# Altium schematic sheet LPC55S69_MINI.SchDoc(LPC55S69_MINI)
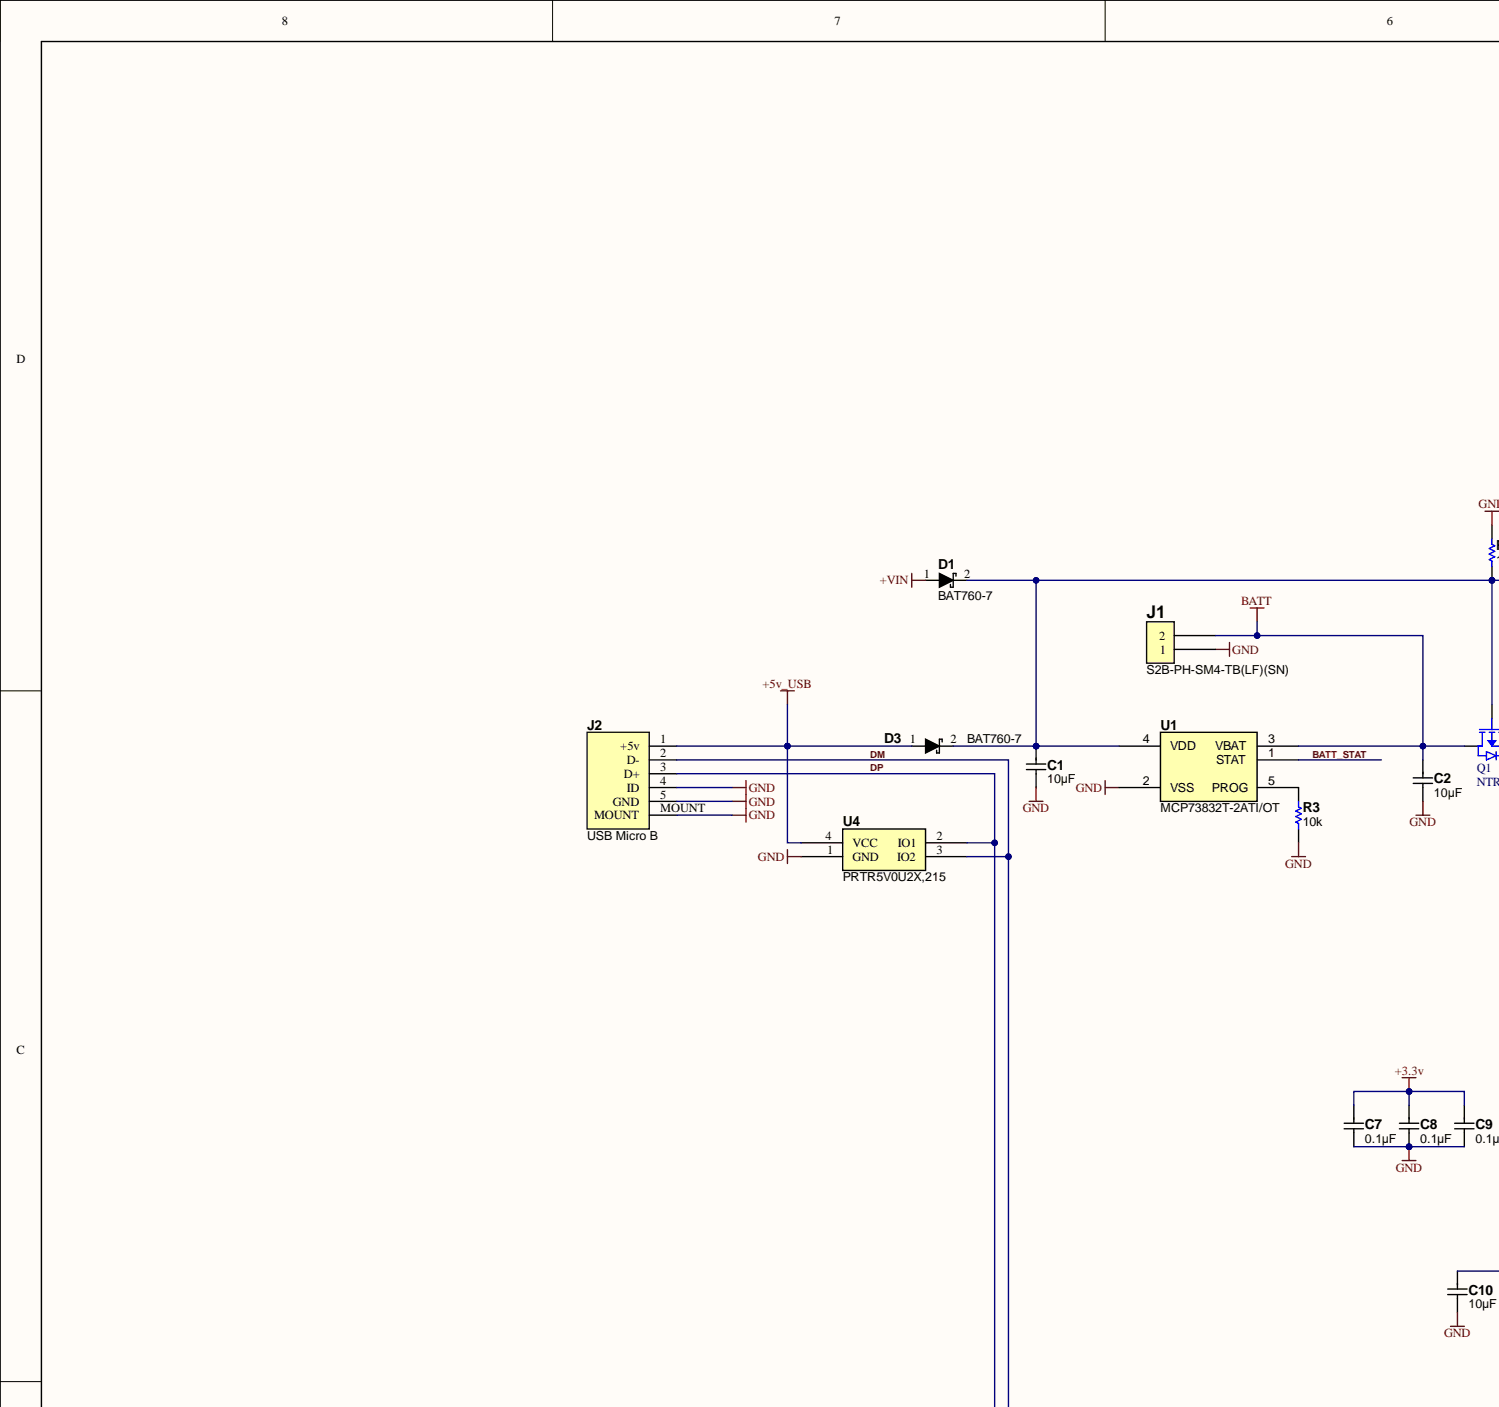
[diagram p0: LPC55S69_MINI.SchDoc, top left]
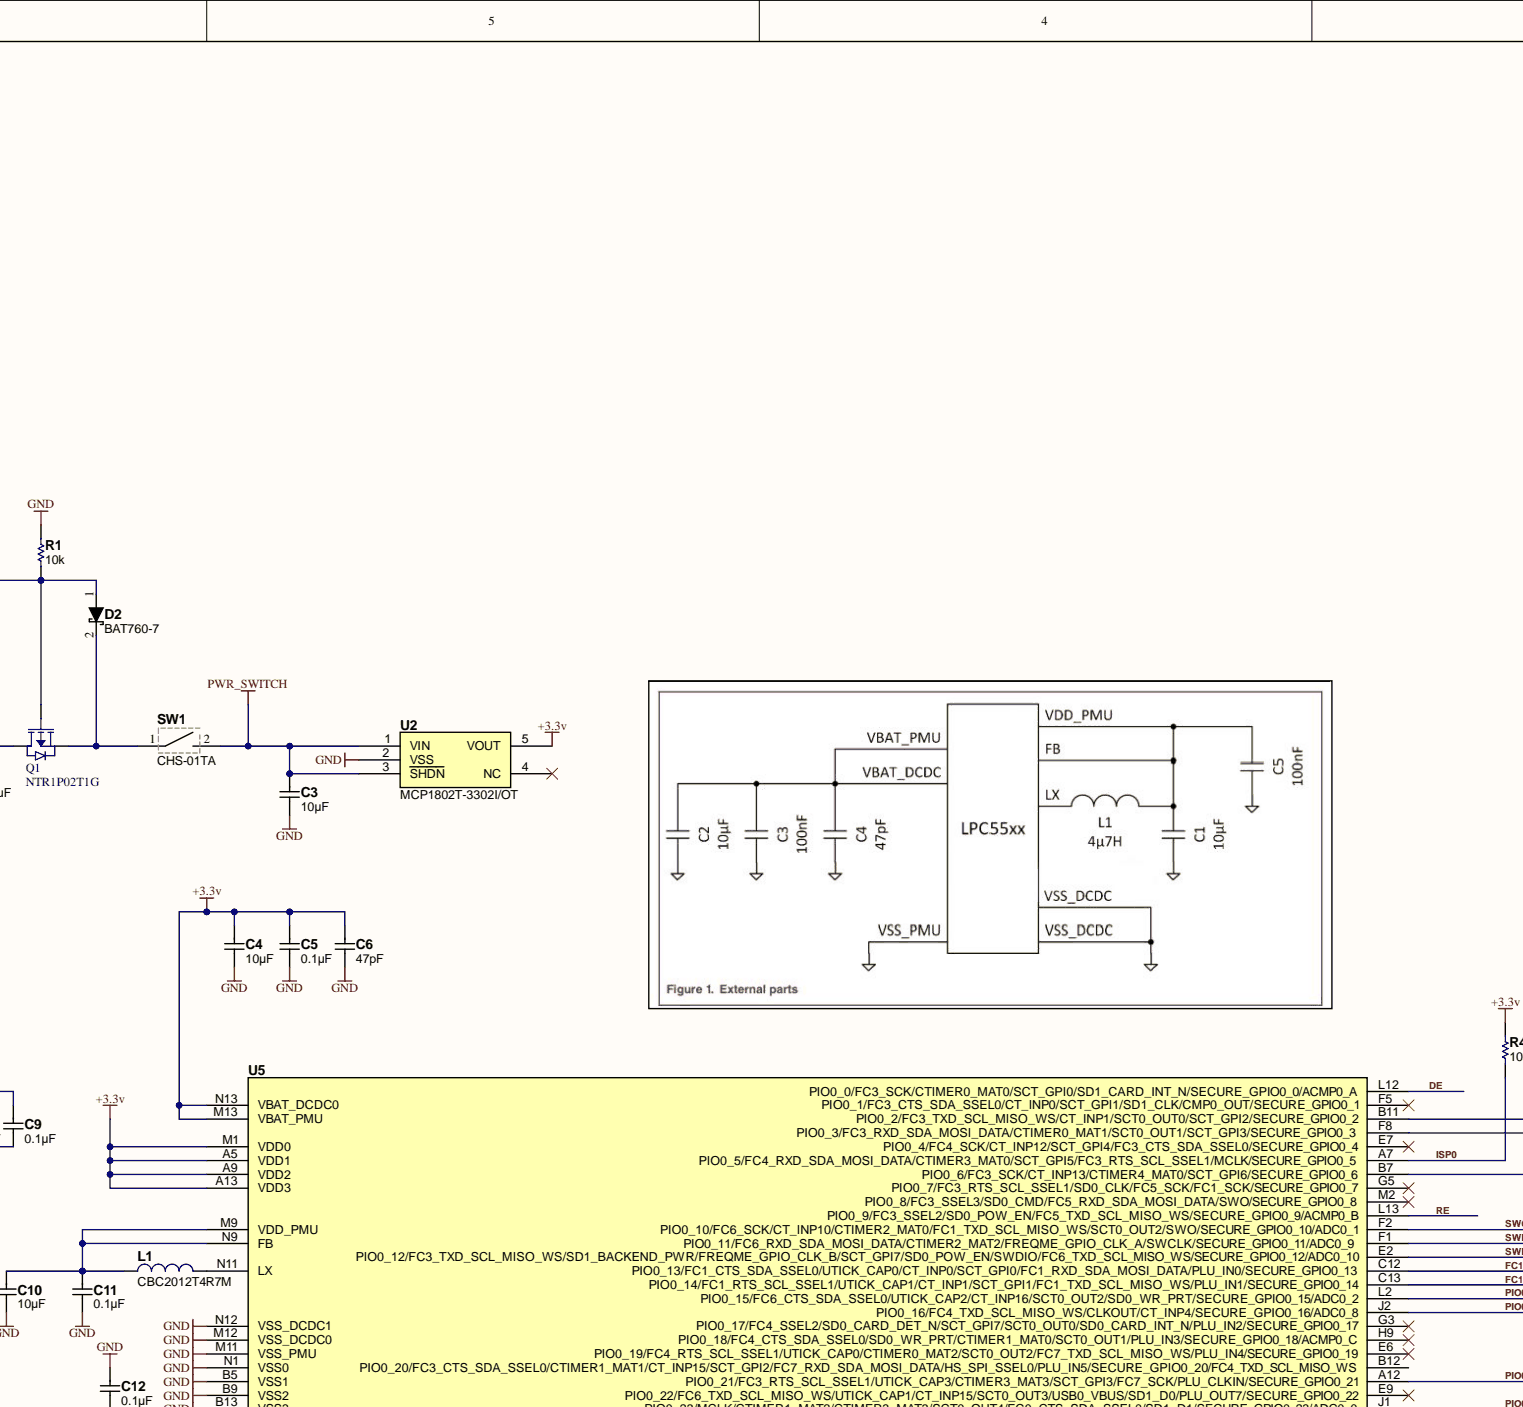
[diagram p1: LPC55S69_MINI.SchDoc, top centre]
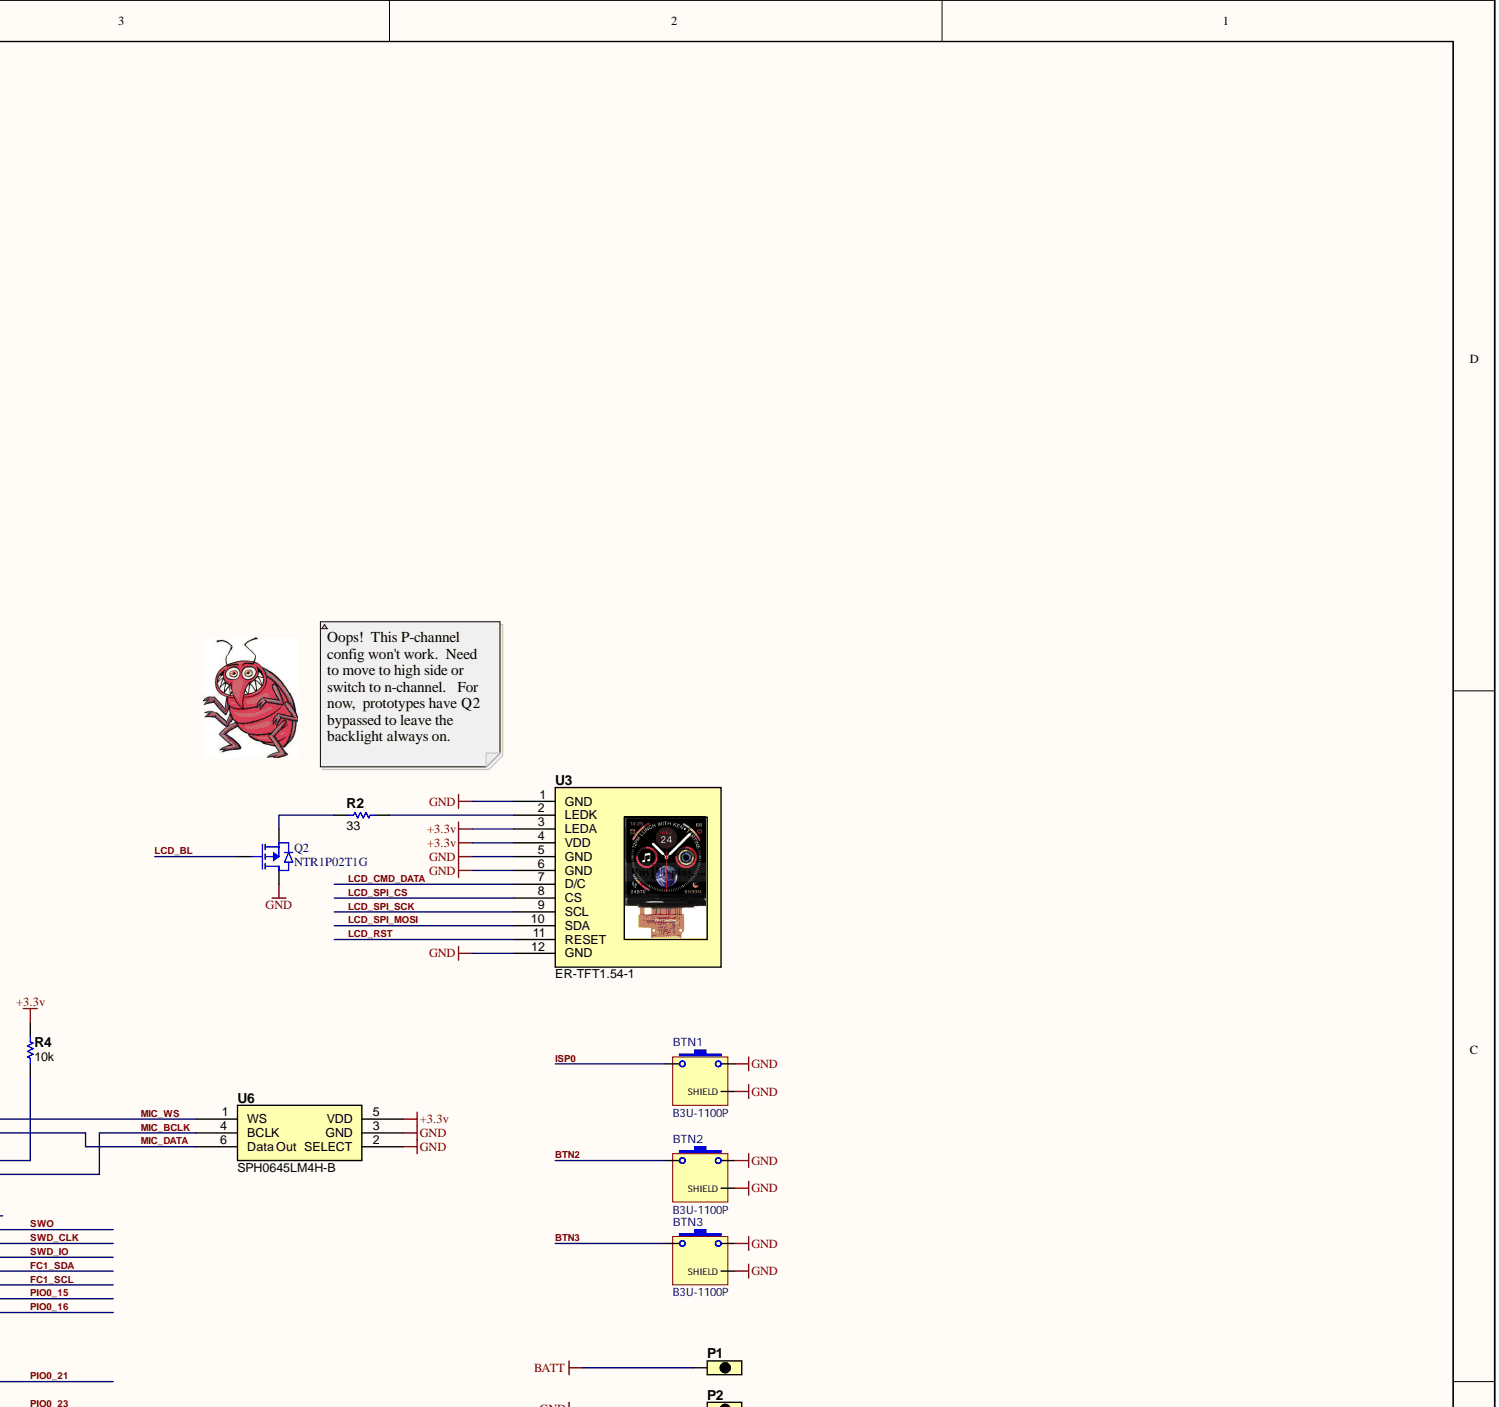
[diagram p2: LPC55S69_MINI.SchDoc, top right]
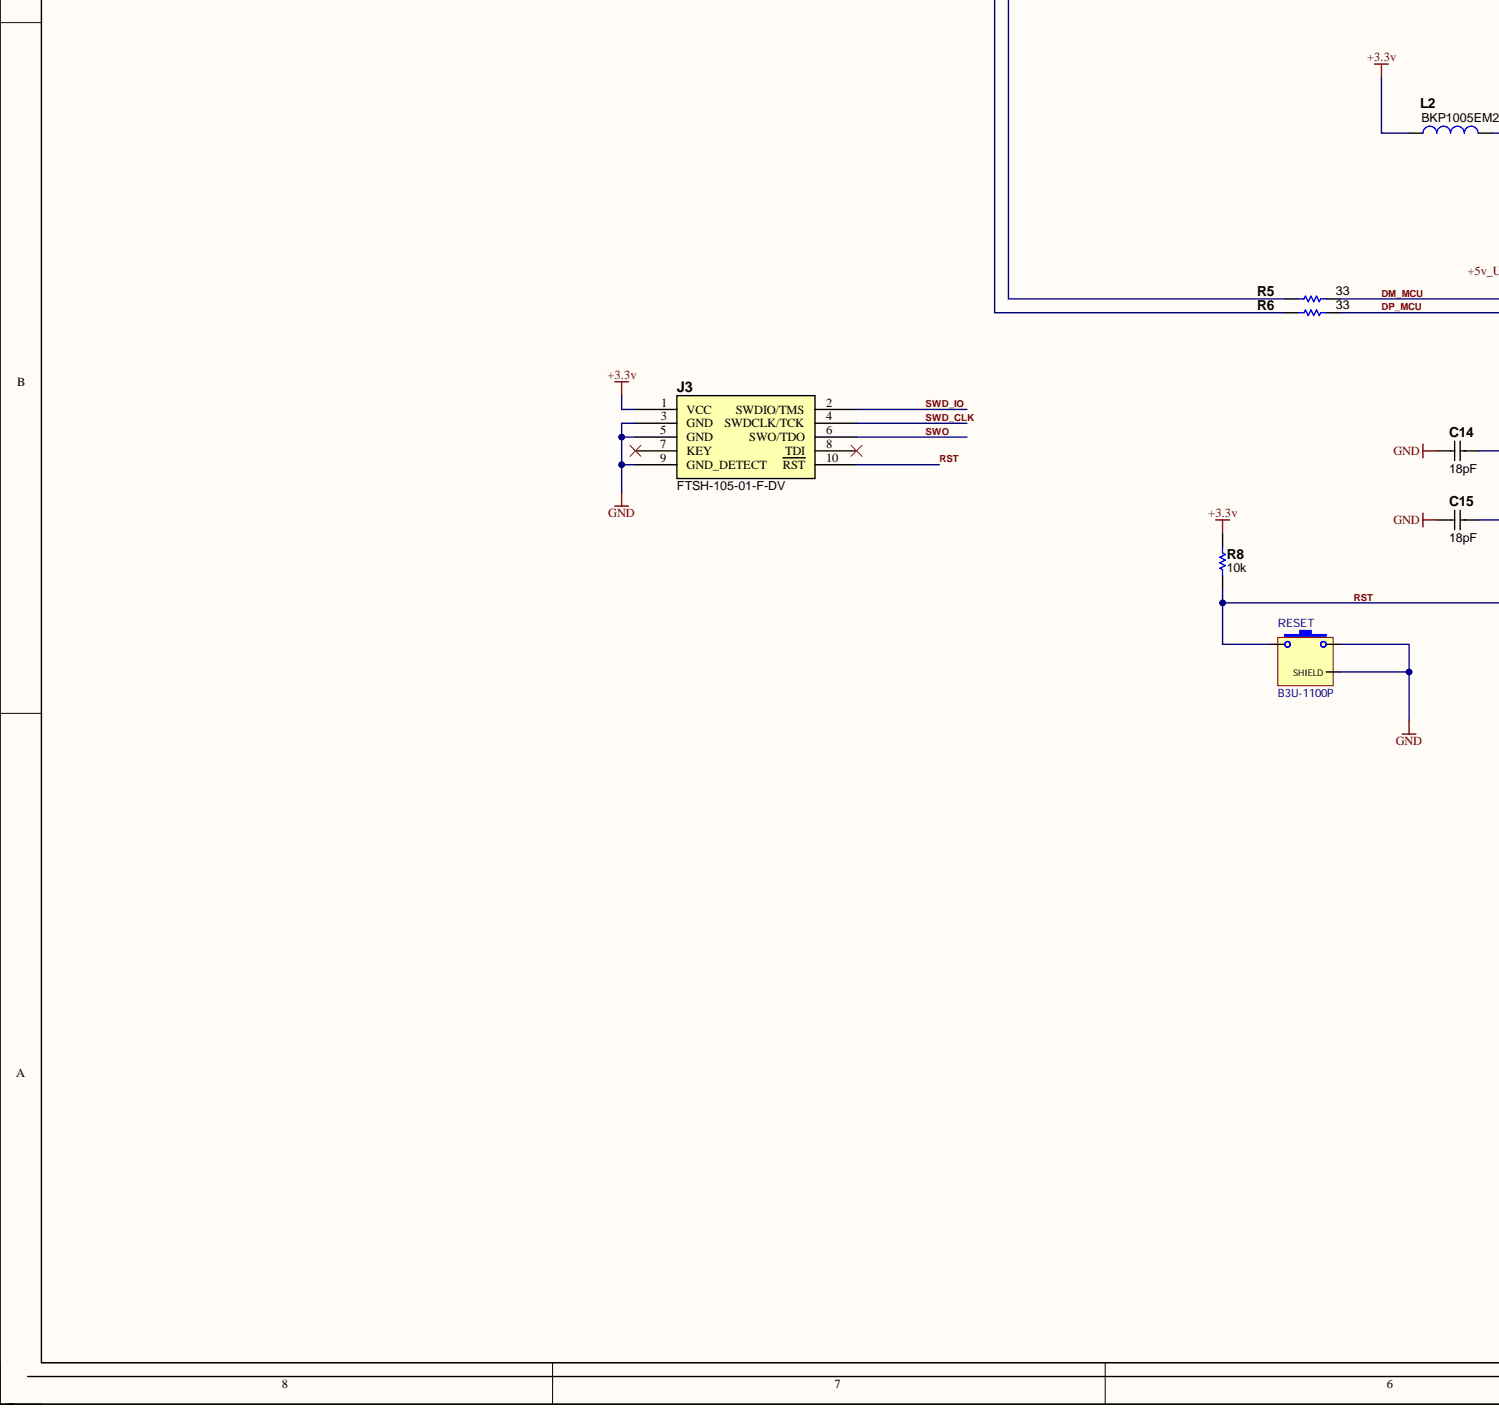
[diagram p3: LPC55S69_MINI.SchDoc, bottom left]
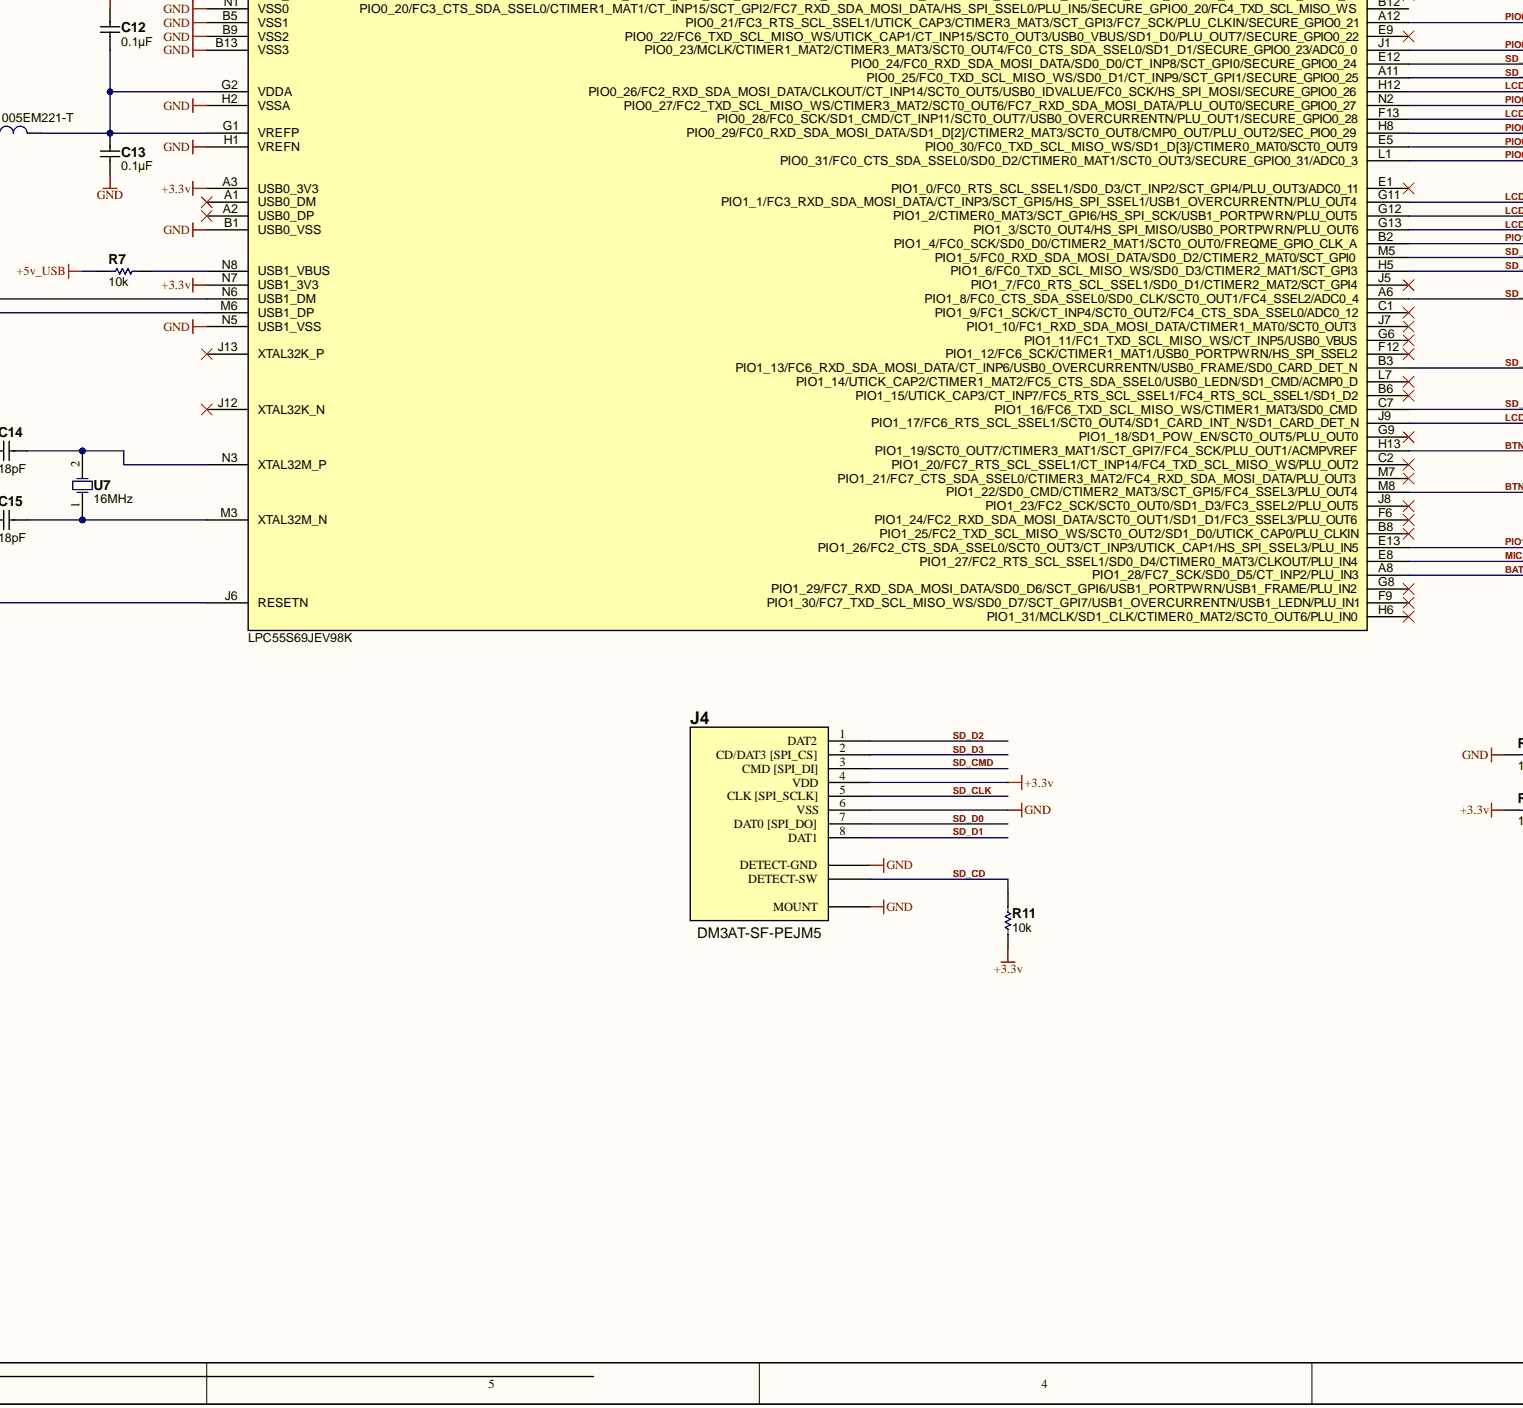
[diagram p4: LPC55S69_MINI.SchDoc, bottom centre]
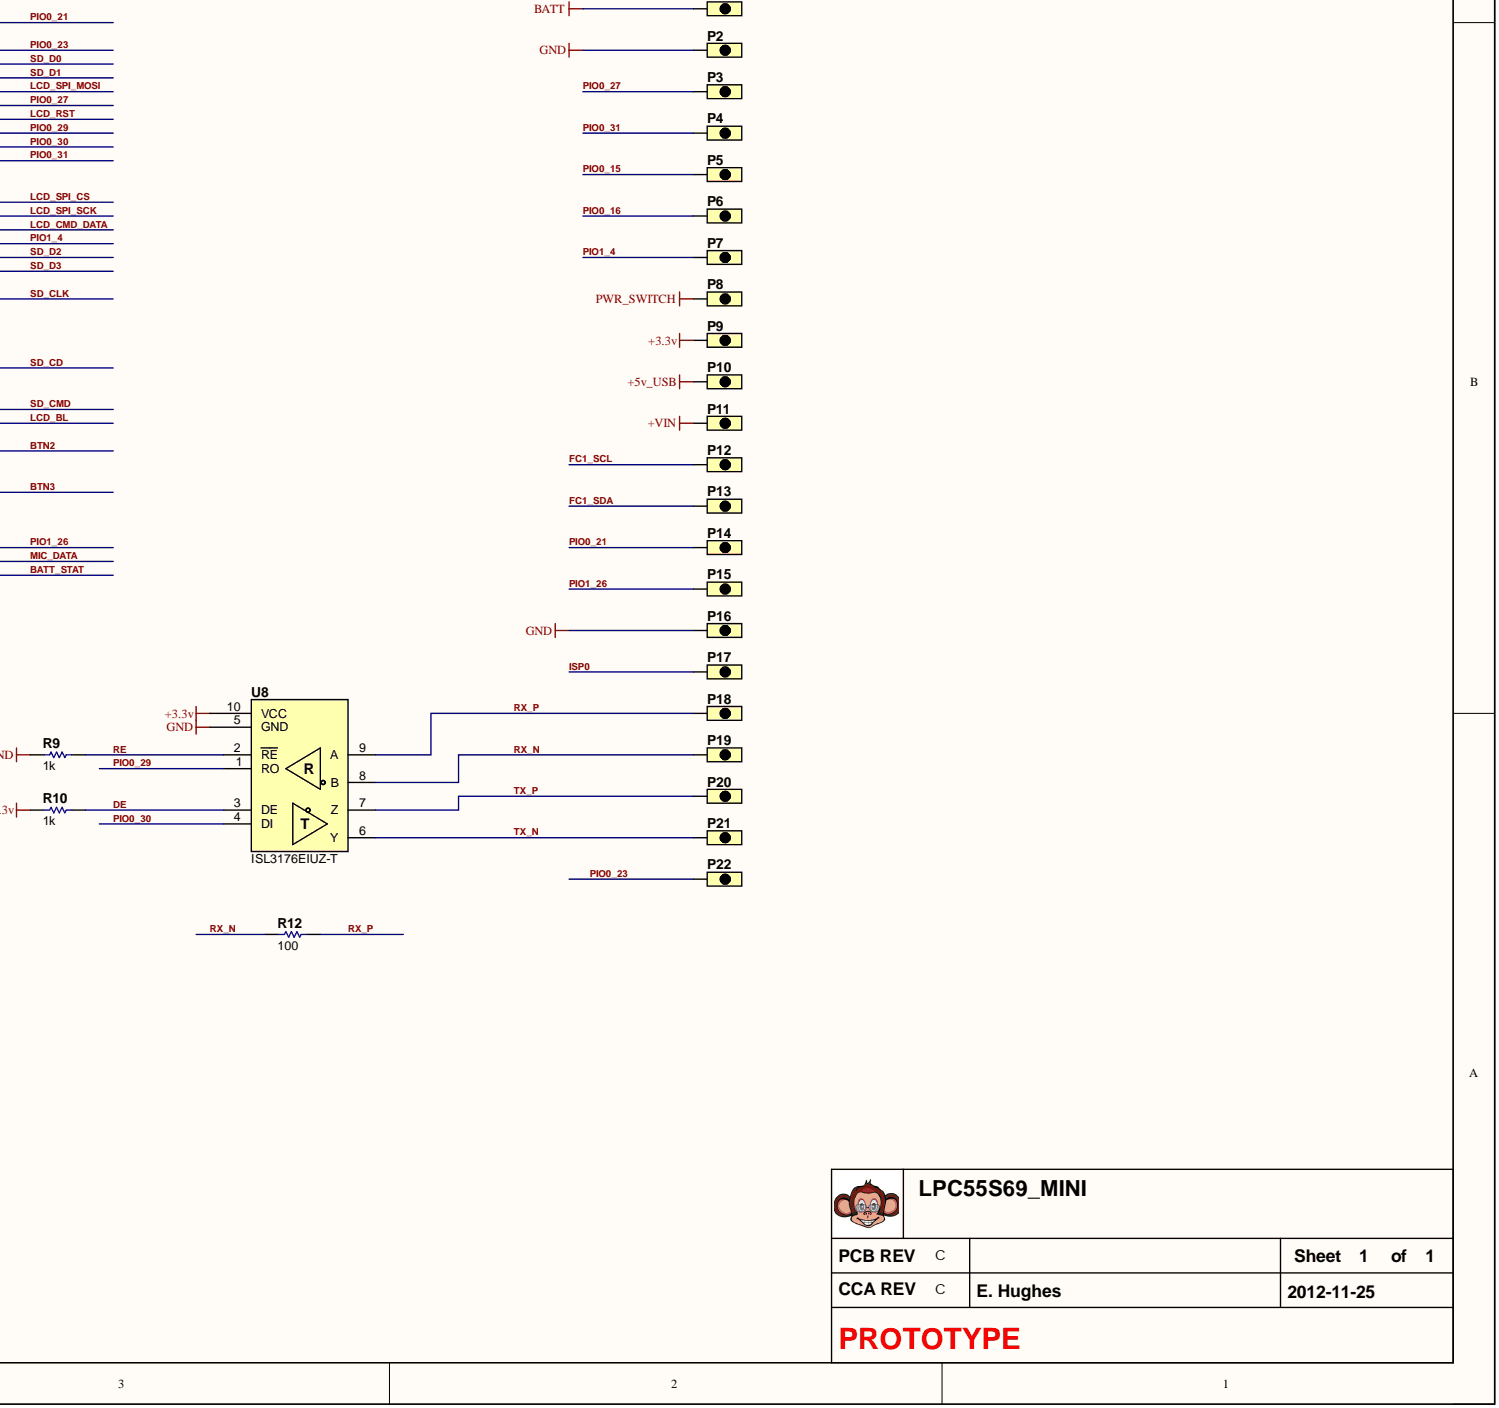
[diagram p5: LPC55S69_MINI.SchDoc, bottom right]

## Parts
BTN1  B3U-1100P  [OMRON_B3U-1100P]
BTN2  B3U-1100P  [OMRON_B3U-1100P]
BTN3  B3U-1100P  [OMRON_B3U-1100P]
C1  10µF  [C0805_1.45MM_MD]
C2  10µF  [C0805_1.45MM_MD]
C3  10µF  [C0805_1.45MM_MD]
C4  10µF  [C0805_1.45MM_MD]
C5  0.1µF  [C0402_0.55MM_MD]
C6  47pF  [C0402_0.55MM_MD]
C7  0.1µF  [C0402_0.55MM_MD]
C8  0.1µF  [C0402_0.55MM_MD]
C9  0.1µF  [C0402_0.55MM_MD]
C10  10µF  [C0805_1.45MM_MD]
C11  0.1µF  [C0402_0.55MM_MD]
C12  0.1µF  [C0402_0.55MM_MD]
C13  0.1µF  [C0402_0.55MM_MD]
C14  18pF  [C0402_0.55MM_MD]
C15  18pF  [C0402_0.55MM_MD]
D1  BAT760-7  [D_SOD-323_P]
D2  BAT760-7  [D_SOD-323_P]
D3  BAT760-7  [D_SOD-323_P]
J1  S2B-PH-SM4-TB(LF)(SN)  [S2B-PH-SM4-TB(LF)(SN)]
J2  USB Micro B  [10103594-0001LF - No Slots]
J3  FTSH-105-01-F-DV  [FTSH-105-01-F-DV-K-A]
J4  DM3AT-SF-PEJM5  [DM3AT-SF-PEJM5]
L1  CBC2012T4R7M  [C0805_1.45MM_MD]
L2  BKP1005EM221-T  [C0402_HD]
P1  (no value)  [CASTELLATED]  (no BOM)
P2  (no value)  [CASTELLATED]  (no BOM)
P3  (no value)  [CASTELLATED]  (no BOM)
P4  (no value)  [CASTELLATED]  (no BOM)
P5  (no value)  [CASTELLATED]  (no BOM)
P6  (no value)  [CASTELLATED]  (no BOM)
P7  (no value)  [CASTELLATED]  (no BOM)
P8  (no value)  [CASTELLATED]  (no BOM)
P9  (no value)  [CASTELLATED]  (no BOM)
P10  (no value)  [CASTELLATED]  (no BOM)
P11  (no value)  [CASTELLATED]  (no BOM)
P12  (no value)  [CASTELLATED]  (no BOM)
P13  (no value)  [CASTELLATED]  (no BOM)
P14  (no value)  [CASTELLATED]  (no BOM)
P15  (no value)  [CASTELLATED]  (no BOM)
P16  (no value)  [CASTELLATED]  (no BOM)
P17  (no value)  [CASTELLATED]  (no BOM)
P18  (no value)  [CASTELLATED]  (no BOM)
P19  (no value)  [CASTELLATED]  (no BOM)
P20  (no value)  [CASTELLATED]  (no BOM)
P21  (no value)  [CASTELLATED]  (no BOM)
P22  (no value)  [CASTELLATED]  (no BOM)
Q1  NTR1P02T1G  [SOT-23]
Q2  NTR1P02T1G  [SOT-23]
R1  10k  [R0402_0.40MM_MD]
R2  33  [R0603_0.55MM_MD]
R3  10k  [R0402_0.40MM_MD]
R4  10k  [R0402_0.40MM_MD]
R5  33  [R0402_0.40MM_MD]
R6  33  [R0402_0.40MM_MD]
R7  10k  [R0402_0.40MM_MD]
R8  10k  [R0402_0.40MM_MD]
R9  1k  [R0402_0.40MM_MD]
R10  1k  [R0402_0.40MM_MD]
R11  10k  [R0402_0.40MM_MD]
R12  100  [R0603_HD]
RESET  B3U-1100P  [OMRON_B3U-1100P]
SW1  CHS-01TA  [CHS-01TA]
U1  MCP73832T-2ATI/OT  [SOT23-5]
U2  MCP1802T-3302I/OT  [SOT23-5]
U3  ER-TFT1.54-1  [ER-TFT1.54-1]
U4  PRTR5V0U2X,215  [SOT143B]
U5  LPC55S69JEV98K  [98-VFBGA]
U6  SPH0645LM4H-B  [SPH0645LM4H-B]
U7  16MHz  [ABM3]
U8  ISL3176EIUZ-T  [10 MSOP]

## Nets
+3.3v: C4.2 C5.2 C6.2 C7.2 C8.2 C9.2 J3.1(VCC) J4.4(VDD) L2.1 P9.1 R4.2 R8.2 R10.1 R11.1 U2.5(VOUT) U3.3(LEDA) U3.4(VDD) U5.A3(USB0_3V3) U5.A5(VDD1) U5.A9(VDD2) U5.A13(VDD3) U5.M1(VDD0) U5.M13(VBAT_PMU) U5.N7(USB1_3V3) U5.N13(VBAT_DCDC0) U6.5(VDD) U8.10(VCC)
+5v_USB: D3.1 J2.1(+5v) P10.1 R7.2 U4.4(VCC)
+VIN: D1.1 P11.1
BATT: C2.2 J1.2 P1.1 Q1.3 U1.3(VBAT)
GND: BTN1.1 BTN1.S BTN2.1 BTN2.S BTN3.1 BTN3.S C1.1 C2.1 C3.1 C4.1 C5.1 C6.1 C7.1 C8.1 C9.1 C10.1 C11.1 C12.2 C13.1 C14.1 C15.1 J1.1 J2.4(ID) J2.5(GND) J2.MOUNT J3.3(GND) J3.5(GND) J3.9(GND_DETECT) J4.6(VSS) J4.CDA(DETECT-GND) J4.M(MOUNT) P2.1 P16.1 Q2.3 R1.2 R3.1 R9.1 RESET.1 RESET.S U1.2(VSS) U2.2(VSS) U3.1(GND) U3.5(GND) U3.6(GND) U3.12(GND) U4.1(GND) U5.B1(USB0_VSS) U5.B5(VSS1) U5.B9(VSS2) U5.B13(VSS3) U5.H1(VREFN) U5.H2(VSSA) U5.M11(VSS_PMU) U5.M12(VSS_DCDC0) U5.N1(VSS0) U5.N5(USB1_VSS) U5.N12(VSS_DCDC1) U6.2(SELECT) U6.3(GND) U8.5(GND)
PWR_SWITCH: C3.2 P8.1 SW1.2 U2.1(VIN) U2.3(SHDN)
BATT_STAT: U1.1(STAT) U5.A8(PIO1_28/FC7_SCK/SD0_D5/CT_INP2/PLU_IN3)
BTN2: BTN2.2 U5.H13(PIO1_19/SCT0_OUT7/CTIMER3_MAT1/SCT_GPI7/FC4_SCK/PLU_OUT1/ACMPVREF)
BTN3: BTN3.2 U5.M8(PIO1_22/SD0_CMD/CTIMER2_MAT3/SCT_GPI5/FC4_SSEL3/PLU_OUT4)
DE: R10.2 U5.L12 U8.3(DE)
DM: J2.2(D-) R5.1 U4.3(IO2)
DM_MCU: R5.2 U5.N6(USB1_DM)
DP: J2.3(D+) R6.1 U4.2(IO1)
DP_MCU: R6.2 U5.M6(USB1_DP)
FC1_SCL: P12.1 U5.C13
FC1_SDA: P13.1 U5.C12
ISP0: BTN1.2 P17.1 R4.1 U5.A7
LCD_BL: Q2.1 U5.J9(PIO1_17/FC6_RTS_SCL_SSEL1/SCT0_OUT4/SD1_CARD_INT_N/SD1_CARD_DET_N)
LCD_CMD_DATA: U3.7(D/C) U5.G13(PIO1_3/SCT0_OUT4/HS_SPI_MISO/USB0_PORTPWRN/PLU_OUT6)
LCD_RST: U3.11(RESET) U5.F13
LCD_SPI_CS: U3.8(CS) U5.G11(PIO1_1/FC3_RXD_SDA_MOSI_DATA/CT_INP3/SCT_GPI5/HS_SPI_SSEL1/USB1_OVERCURRENTN/PLU_OUT4)
LCD_SPI_MOSI: U3.10(SDA) U5.H12
LCD_SPI_SCK: U3.9(SCL) U5.G12(PIO1_2/CTIMER0_MAT3/SCT_GPI6/HS_SPI_SCK/USB1_PORTPWRN/PLU_OUT5)
MIC_BCLK: U5.B7 U6.4(BCLK)
MIC_DATA: U5.E8(PIO1_27/FC2_RTS_SCL_SSEL1/SD0_D4/CTIMER0_MAT3/CLKOUT/PLU_IN4) U5.F8 U6.6(Data_Out)
MIC_WS: U5.B11 U6.1(WS)
PIO0_15: P5.1 U5.L2
PIO0_16: P6.1 U5.J2
PIO0_21: P14.1 U5.A12
PIO0_23: P22.1 U5.J1
PIO0_27: P3.1 U5.N2
PIO0_29: U5.H8 U8.1(RO)
PIO0_30: U5.E5 U8.4(DI)
PIO0_31: P4.1 U5.L1
PIO1_4: P7.1 U5.B2(PIO1_4/FC0_SCK/SD0_D0/CTIMER2_MAT1/SCT0_OUT0/FREQME_GPIO_CLK_A)
PIO1_26: P15.1 U5.E13(PIO1_26/FC2_CTS_SDA_SSEL0/SCT0_OUT3/CT_INP3/UTICK_CAP1/HS_SPI_SSEL3/PLU_IN5)
RE: R9.2 U5.L13 U8.2(RE)
RST: J3.10(RST) R8.1 RESET.2 U5.J6(RESETN)
RX_N: P19.1 R12.2 U8.8(B)
RX_P: P18.1 R12.1 U8.9(A)
SD_CD: J4.CDB(DETECT-SW) R11.2 U5.B3(PIO1_13/FC6_RXD_SDA_MOSI_DATA/CT_INP6/USB0_OVERCURRENTN/USB0_FRAME/SD0_CARD_DET_N)
SD_CLK: J4.5(CLK_[SPI_SCLK]) U5.A6(PIO1_8/FC0_CTS_SDA_SSEL0/SD0_CLK/SCT0_OUT1/FC4_SSEL2/ADC0_4)
SD_CMD: J4.3(CMD_[SPI_DI]) U5.C7(PIO1_16/FC6_TXD_SCL_MISO_WS/CTIMER1_MAT3/SD0_CMD)
SD_D0: J4.7(DAT0_[SPI_DO]) U5.E12
SD_D1: J4.8(DAT1) U5.A11
SD_D2: J4.1(DAT2) U5.M5(PIO1_5/FC0_RXD_SDA_MOSI_DATA/SD0_D2/CTIMER2_MAT0/SCT_GPI0)
SD_D3: J4.2(CD/DAT3_[SPI_CS]) U5.H5(PIO1_6/FC0_TXD_SCL_MISO_WS/SD0_D3/CTIMER2_MAT1/SCT_GPI3)
SWD_CLK: J3.4(SWDCLK/TCK) U5.F1
SWD_IO: J3.2(SWDIO/TMS) U5.E2
SWO: J3.6(SWO/TDO) U5.F2
TX_N: P21.1 U8.6(Y)
TX_P: P20.1 U8.7(Z)
NetC1_2: C1.2 D1.2 D2.1 D3.2 Q1.1 R1.1 U1.4(VDD)
NetC10_2: C10.2 C11.2 L1.1 U5.M9(VDD_PMU) U5.N9(FB)
NetC12_1: C12.1 C13.2 L2.2 U5.G1(VREFP) U5.G2(VDDA)
NetC14_2: C14.2 U5.N3(XTAL32M_P) U7.2
NetC15_2: C15.2 U5.M3(XTAL32M_N) U7.1
NetD2_2: D2.2 Q1.2 SW1.1
NetL1_2: L1.2 U5.N11(LX)
NetQ2_2: Q2.2 R2.1
NetR2_2: R2.2 U3.2(LEDK)
NetR3_2: R3.2 U1.5(PROG)
NetR7_1: R7.1 U5.N8(USB1_VBUS)

Not connected: J3 7(KEY) 8(TDI); U2 4(NC); U5 A1(USB0_DM) A2(USB0_DP) B6(PIO1_15/UTICK_CAP3/CT_INP7/FC5_RTS_SCL_SSEL1/FC4_RTS_SCL_SSEL1/SD1_D2) B8(PIO1_25/FC2_TXD_SCL_MISO_WS/SCT0_OUT2/SD1_D0/UTICK_CAP0/PLU_CLKIN) B12 C1(PIO1_9/FC1_SCK/CT_INP4/SCT0_OUT2/FC4_CTS_SDA_SSEL0/ADC0_12) C2(PIO1_20/FC7_RTS_SCL_SSEL1/CT_INP14/FC4_TXD_SCL_MISO_WS/PLU_OUT2) E1(PIO1_0/FC0_RTS_SCL_SSEL1/SD0_D3/CT_INP2/SCT_GPI4/PLU_OUT3/ADC0_11) E6 E7 E9 F5 F6(PIO1_24/FC2_RXD_SDA_MOSI_DATA/SCT0_OUT1/SD1_D1/FC3_SSEL3/PLU_OUT6) F9(PIO1_30/FC7_TXD_SCL_MISO_WS/SD0_D7/SCT_GPI7/USB1_OVERCURRENTN/USB1_LEDN/PLU_IN1) F12(PIO1_12/FC6_SCK/CTIMER1_MAT1/USB0_PORTPWRN/HS_SPI_SSEL2) G3 G5 G6(PIO1_11/FC1_TXD_SCL_MISO_WS/CT_INP5/USB0_VBUS) G8(PIO1_29/FC7_RXD_SDA_MOSI_DATA/SD0_D6/SCT_GPI6/USB1_PORTPWRN/USB1_FRAME/PLU_IN2) G9(PIO1_18/SD1_POW_EN/SCT0_OUT5/PLU_OUT0) H6(PIO1_31/MCLK/SD1_CLK/CTIMER0_MAT2/SCT0_OUT6/PLU_IN0) H9 J5(PIO1_7/FC0_RTS_SCL_SSEL1/SD0_D1/CTIMER2_MAT2/SCT_GPI4) J7(PIO1_10/FC1_RXD_SDA_MOSI_DATA/CTIMER1_MAT0/SCT0_OUT3) J8(PIO1_23/FC2_SCK/SCT0_OUT0/SD1_D3/FC3_SSEL2/PLU_OUT5) J12(XTAL32K_N) J13(XTAL32K_P) L7(PIO1_14/UTICK_CAP2/CTIMER1_MAT2/FC5_CTS_SDA_SSEL0/USB0_LEDN/SD1_CMD/ACMP0_D) M2 M7(PIO1_21/FC7_CTS_SDA_SSEL0/CTIMER3_MAT2/FC4_RXD_SDA_MOSI_DATA/PLU_OUT3)
